# Altium schematic sheet ppg.SchDoc
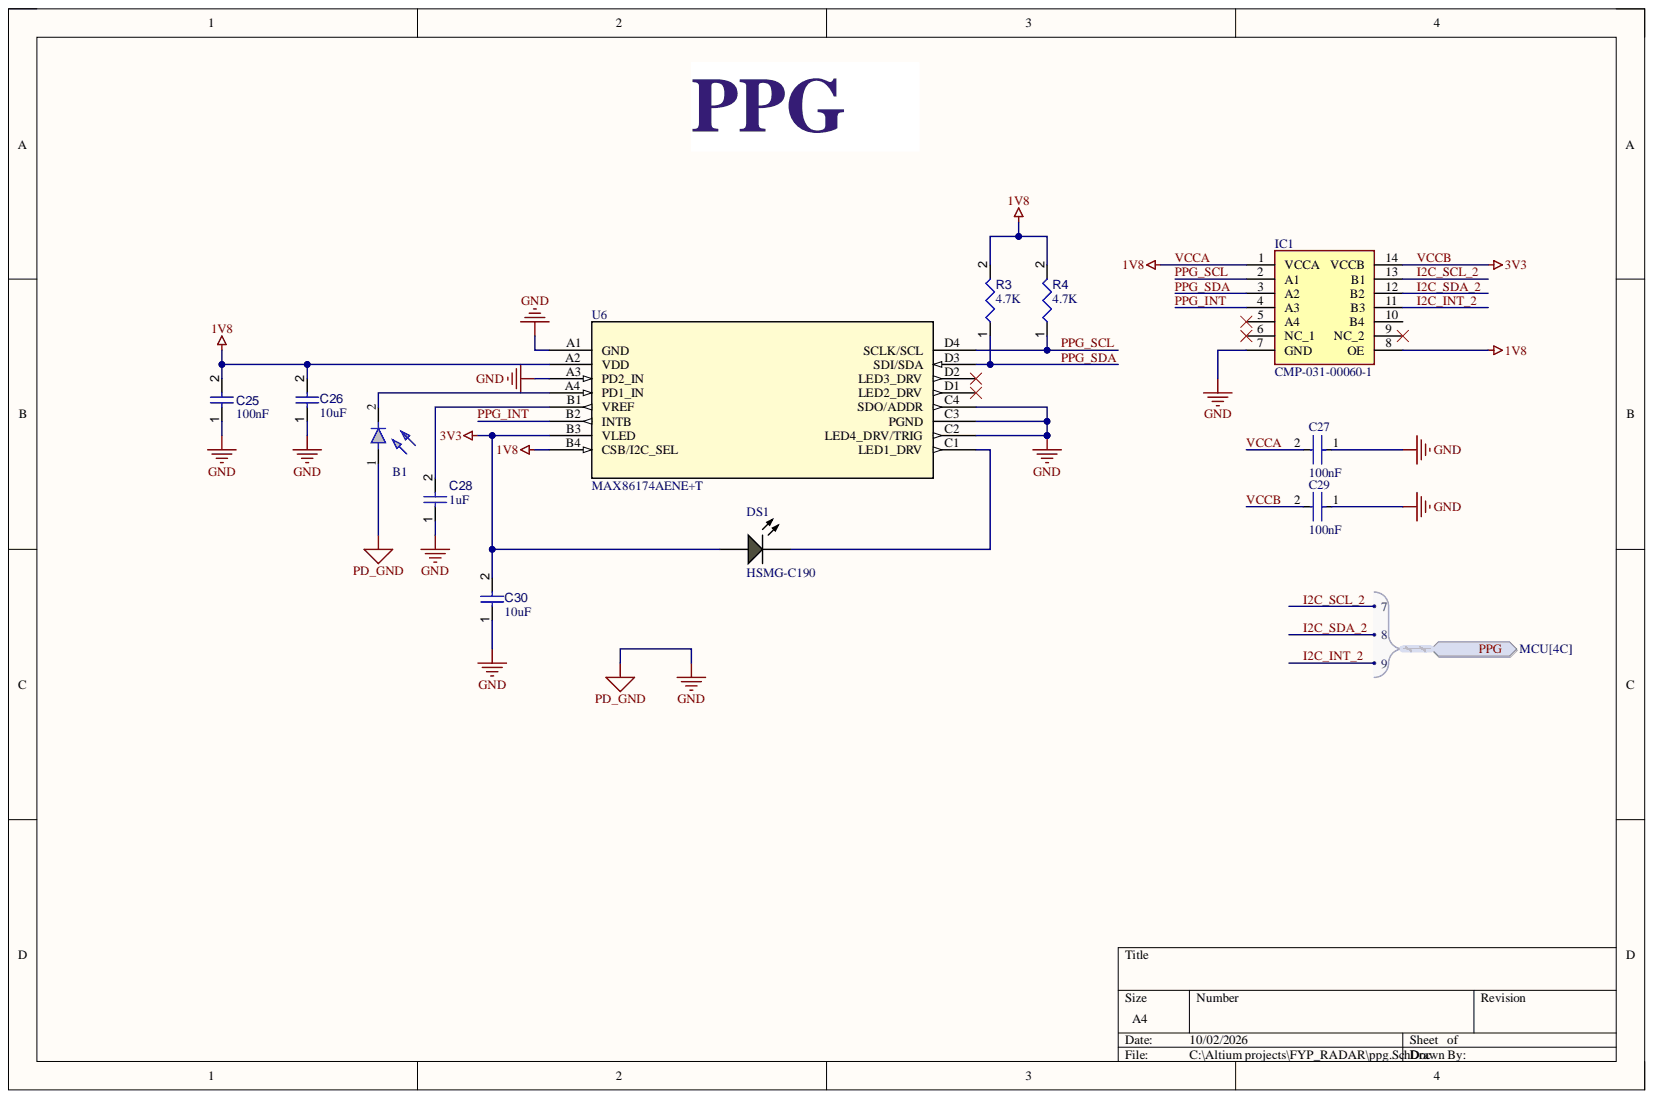
[diagram p0: ppg.SchDoc]

## Parts
B1  SFH_2770_A01  [SFH2770A01]  (value not drawn)
C25  value 100nF  [CAPC1608X90X40NL10T20]  (hidden comment: Capacitor 100 nF +/-10% 50 V 0603)
C26  value 10uF  [CAPC0603(1608)100_L]  (hidden comment: Capacitor 10µF +/-20% 10V 0603)
C27  100nF  [FP-C0402-BB-MFG]
C28  value 1uF  [CAPC1608X94X35NL15T15]  (hidden comment: Capacitor 1 uF +/- 10% 16 V 0603)
C29  100nF  [FP-C0402-BB-MFG]
C30  value 10uF  [CAPC0603(1608)100_L]  (hidden comment: Capacitor 10µF +/-20% 10V 0603)
DS1  HSMG-C190  [AVAG-HSMX-C190_V]
IC1  CMP-031-00060-1  [SOP65P640X120-14N]
R3  value 4.7K  [RESC1608X55X25ML10T15]  (hidden comment: Resistor 4k7 +/-1% 0603 100 mW)
R4  value 4.7K  [RESC1608X55X25ML10T15]  (hidden comment: Resistor 4k7 +/-1% 0603 100 mW)
U6  MAX86174AENE+T  [21-100454_N161B1+1_MXM]

## Ports
PPG, PPG.7, PPG.8, PPG.9

## Nets
GND: B1.1 C25.1 C26.1 C27.1 C28.1 C29.1 C30.1 IC1.7(GND) U6.A1(GND) U6.A3(PD2_IN) U6.C2(LED4_DRV/TRIG) U6.C3(PGND) U6.C4(SDO/ADDR)
I2C_INT_2: IC1.11(B3) ; port PPG
I2C_SCL_2: IC1.13(B1) ; port PPG
I2C_SDA_2: IC1.12(B2) ; port PPG
PPG_INT: IC1.4(A3) U6.B2(INTB)
PPG_SCL: IC1.2(A1) R4.1 U6.D4(SCLK/SCL)
PPG_SDA: IC1.3(A2) R3.1 U6.D3(SDI/SDA)
VCCA: C25.2 C26.2 C27.2 IC1.1(VCCA) IC1.8(OE) R3.2 R4.2 U6.A2(VDD) U6.B4(CSB/I2C_SEL)
VCCB: C29.2 C30.2 DS1.1 IC1.14(VCCB) U6.B3(VLED)
NetB1_2: B1.2 U6.A4(PD1_IN)
NetC28_2: C28.2 U6.B1(VREF)
NetDS1_2: DS1.2 U6.C1(LED1_DRV)

Not connected: IC1 5(A4) 6(NC_1) 9(NC_2) 10(B4); U6 D1(LED2_DRV) D2(LED3_DRV)

## Library data of the parts
B1 = SFH_2770_A01: Photodiode 560nm 500ns 0805 (2012 Metric); Manufacturer_Name: ams OSRAM; Manufacturer_Part_Number: SFH 2770 A01; Geometry.Height: 1mm; Component Kind: Standard; Datasheet Link: https://ams-osram.com/products/photodetectors/photodiodes/osram-chip-led-0805-sfh-2770-a01; Library Name: SFH_2770_A01.SchLib
C25 = CMP-001-00017-6: Chip Capacitor, 100 nF, +/- 10%, 50 V, 0603 (1608 Metric); Capacitor Type: Ceramic; Voltage Rating: 50V; Case/Package: 0603; Component Kind: Standard; Max Operating Temperature: 125°C; Min Operating Temperature: -55°C; Mounting Technology: SM; Pins: 2; RoHS Compliant: True; Tolerance: 10%; Library Name: Kopithan Manoranjithan
C26 C30 = CMP-001-00075-4: Chip Capacitor, 10µF +/-20%, 10V, 0603, Thickness 1 mm; Tolerance: 10%; Case/Package: 0603; Component Kind: Standard; Max Operating Temperature: 85°C; Min Operating Temperature: -55°C; Mounting Technology: SMT; Pins: 2; Voltage Rating: 10V; Library Name: Kopithan Manoranjithan
C27 C29 = CMP-03020-014070-2: CAP CER 0.1UF 25V X5R 0402; Manufacturer: Kemet; Manufacturer Part Number: C0402C104M3PAC7867; Capacitance: 0.10 μF; Material: Ceramic; Temperature Coefficient: 15%; Tolerance: 0.2; Value: 0.10 μF; Automotive: No; Category: Cap; Component Kind: Standard; ComponentLink2Description: Datasheet; ComponentLink2URL: https://content.kemet.com/datasheets/KEM_C1002_X7R_SMD.pdf; Device Class L1: Passive Components; Device Class L2: Capacitors; Device Class L3: Ceramic Capacitors; Digikey Description: CAP CER 0.1UF 25V X5R 0402; Height: 0.55mm; Ipc Land Pattern Name: CAPC10050X50; Lead Free: Yes; Library Name: Altium Content Vault; Octopart: https://octopart.com/c0402c104m3pac7867-kemet-84304880; Package: C0402-BB; RoHS: TRUE; Temperature: -55°C to +125°C; Temperature Characteristic: X5R; Temperature Range High: +125°C; Temperature Range Low: -55°C; Voltage Rating: 25 V
C28 = CMP-001-00003-6: Chip Capacitor, 1 uF, +/- 10%, 16 V, 0603 (1608 Metric); Capacitor Type: Ceramic; Voltage Rating: 16V; Case/Package: 0603; Component Kind: Standard; Max Operating Temperature: 125°C; Min Operating Temperature: -55°C; Mounting Technology: SM; Pins: 2; RoHS Compliant: True; Tolerance: 10%; Library Name: Kopithan Manoranjithan
DS1 = CMP-007-00013-2: LED Uni-Color Green, 52 mW, 20 mA, -40 to 85 degC, 2-Pin SMD, RoHS, Tape and Reel; Manufacturer: Avago; Forward Current: 20mA; Forward Voltage: 2.2V; Lens Style: Diffused; Luminous Intensity: 15mcd; Power: 52mW; Viewing Angle: 170degrees; Case/Package: 0603; Colour: Green; Component Kind: Standard; Datasheet URL: http://docs.avagotech.com/docs/AV02-0551EN; Datasheet Version: Mar-12; Illumination Colour: Green; Manufacturer URL: http://www.avagotech.com/; Max Operating Temperature: 85°C; Min Operating Temperature: -40°C; Mounting Technology: SM; Nominal Vf: 2.2V; Package Description: 2-Pin Surface Mount Device, Body 1.6 x 0.8 mm, Height 0.9 mm; Package Quantity: 4000; Package Reference: HSMX-C190; Packaging: TapeandReel; Pins: 2; RoHS Compliant: True; Library Name: Kopithan Manoranjithan
IC1 = CMP-031-00060-1: TXS0104EPWR; Manufacturer_Name: Texas Instruments; Arrow Part Number: TXS0104EPWR; Arrow Price/Stock: https://www.arrow.com/en/products/txs0104epwr/texas-instruments.html?utm_currency=USD&region=nac; Component Kind: Standard; Datasheet Link: http://www.ti.com/lit/ds/symlink/txs0104e.pdf; Design Item ID: TXS0104EPWR; Height: 1.2mm; Manufacturer_Part_Number: TXS0104EPWR; Mouser Part Number: 595-TXS0104EPWR; Mouser Price/Stock: https://www.mouser.co.uk/ProductDetail/Texas-Instruments/TXS0104EPWR?qs=7kv20MEVUlglsv2MH6ZndA%3D%3D; Source: SamacSys.SchLib; Library Name: Kopithan Manoranjithan
R3 R4 = CMP-009-00031-5: Chip Resistor, 4.7 KOhm, +/- 1%, 0.1 W, -55 to 155 degC, 0603 (1608 Metric); Power: 100mW; Case/Package: 0603; Component Kind: Standard; Max Operating Temperature: 155°C; Min Operating Temperature: -55°C; Mounting Technology: SM; Pins: 2; RoHS Compliant: True; Tolerance: 1%; Library Name: Kopithan Manoranjithan
U6 = MAX86174AENE+T: Best-in-Class Optical Pulse Oximeter and Heart-Rate Sensor AFE for Wearable Health; Manufacturer_Name: Analog Devices Inc; Component Kind: Standard; Library Name: MAX86174AENE&plus_T.SchLib; Manufacturer_Part_Number: MAX86174AENE+T; RefDes: RefDes; Type: Type; Copyright: Copyright (C) 2025 Ultra Librarian. All rights reserved.
